# Altium schematic sheet yLINUX-2530-2022V10.SchDoc
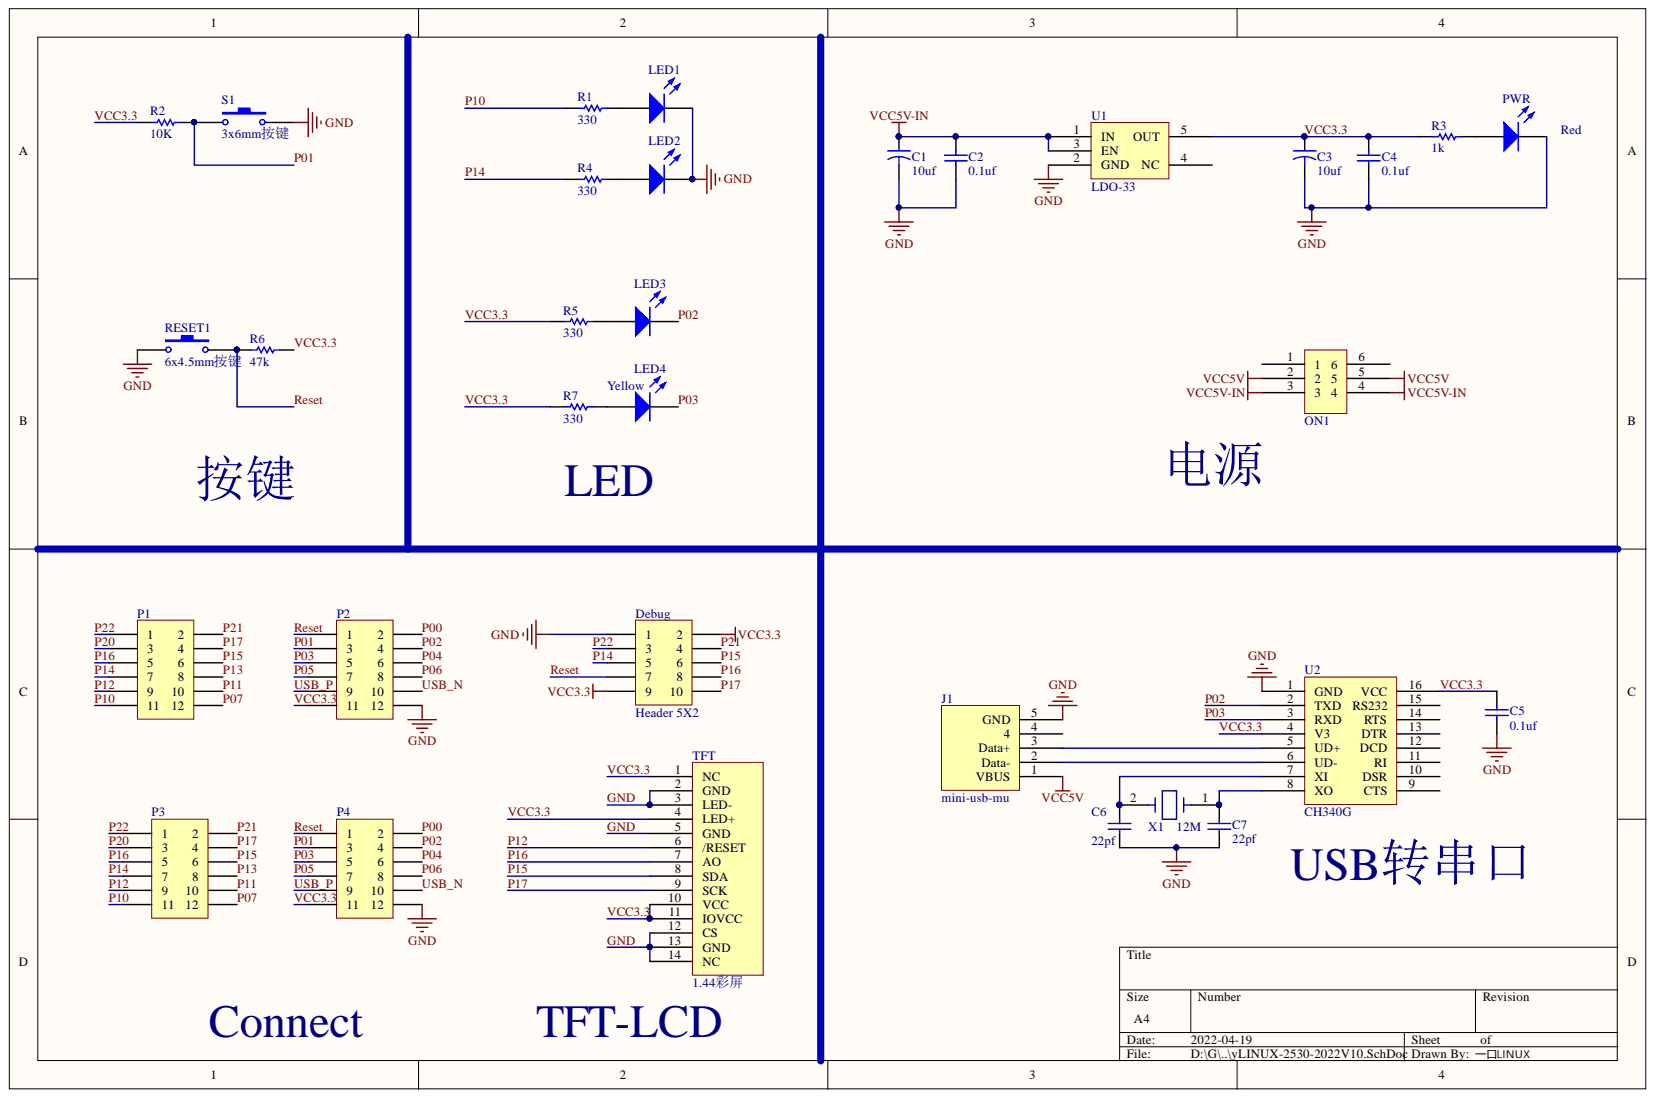
[diagram p0: yLINUX-2530-2022V10.SchDoc]

## Parts
C1  10uf  [10uf钽电容]
C2  0.1uf  [1608[0603]]
C3  10uf  [10uf钽电容]
C4  0.1uf  [1608[0603]]
C5  0.1uf  [1608[0603]]
C6  22pf  [1608[0603]]
C7  22pf  [1608[0603]]
Debug  Header 5X2  [HDR2X5]
J1  mini-usb-mu  [mini-usb-mu]
LED1  (no value)  [C0805]
LED2  (no value)  [C0805]
LED3  (no value)  [C0805]
LED4  (no value)  [C0805]
ON1  6脚开关  [6脚开关]  (value not drawn)
P1  Header 6X2  [HDR2X6]  (value not drawn)
P2  Header 6X2  [HDR2X6]  (value not drawn)
P3  Header 6X2  [HDR2X6]  (value not drawn)
P4  Header 6X2  [HDR2X6]  (value not drawn)
PWR  (no value)  [C0805]
R1  330  [1608[0603]]
R2  10k  [1608[0603]]
R3  1k  [1608[0603]]
R4  330  [1608[0603]]
R5  330  [1608[0603]]
R6  47k  [1608[0603]]
R7  330  [1608[0603]]
RESET1  6x4.5mm按键  [3*6贴片按钮]
S1  3x6mm按键  [3*6贴片按钮]
TFT  1.44彩屏  [1.44TFT]
U1  LDO-33  [LDO-33]
U2  CH340G  [SOIC16]
X1  12M  [HC-49SMD]

## Nets
VCC5V: J1.1(VBUS) ON1.2 ON1.5
VCC5V-IN: C1.1 C2.1 ON1.3 ON1.4 U1.1(IN) U1.3(EN)
GND: C1.2 C2.2 C3.2 C4.2 C5.1 C6.1 C7.1 Debug.1 J1.5(GND) LED1.2 LED2.2 P2.12 P4.12 PWR.2 RESET1.1 S1.2 TFT.2(GND) TFT.3(LED-) TFT.5(GND) TFT.12(CS) TFT.13(GND) TFT.14(NC) U1.2(GND) U2.1(GND)
P00: P2.2 P4.2
P01: P2.3 P4.3 R2.2 S1.1
P02: LED3.2 P2.4 P4.4 U2.2(TXD)
P03: LED4.2 P2.5 P4.5 U2.3(RXD)
P04: P2.6 P4.6
P05: P2.7 P4.7
P06: P2.8 P4.8
P07: P1.12 P3.12
P10: P1.11 P3.11 R1.1
P11: P1.10 P3.10
P12: P1.9 P3.9 TFT.6(/RESET)
P13: P1.8 P3.8
P14: Debug.5 P1.7 P3.7 R4.1
P15: Debug.6 P1.6 P3.6 TFT.8(SDA)
P16: Debug.8 P1.5 P3.5 TFT.7(AO)
P17: Debug.10 P1.4 P3.4 TFT.9(SCK)
P20: P1.3 P3.3
P21: Debug.4 P1.2 P3.2
P22: Debug.3 P1.1 P3.1
Reset: Debug.7 P2.1 P4.1 R6.1 RESET1.2
USB_N: P2.10 P4.10
USB_P: P2.9 P4.9
VCC3.3: C3.1 C4.1 C5.2 Debug.2 Debug.9 P2.11 P4.11 R2.1 R3.1 R5.1 R6.2 R7.1 TFT.1(NC) TFT.4(LED+) TFT.10(VCC) TFT.11(IOVCC) U1.5(OUT) U2.4(V3) U2.16(VCC)
NetC6_2: C6.2 U2.7(XI) X1.2
NetC7_2: C7.2 U2.8(XO) X1.1
NetJ1_2: J1.2(Data-) U2.6(UD-)
NetJ1_3: J1.3(Data+) U2.5(UD+)
NetLED1_1: LED1.1 R1.2
NetLED2_1: LED2.1 R4.2
NetLED3_1: LED3.1 R5.2
NetLED4_1: LED4.1 R7.2
NetPWR_1: PWR.1 R3.2

Not connected: J1 4; ON1 1 6; U1 4(NC); U2 9(CTS) 10(DSR) 11(RI) 12(DCD) 13(DTR) 14(RTS) 15(RS232)

## Library data of the parts
C1 C3 = Cap2: Capacitor; Component Kind: Standard; Library Name: 我的元件库.IntLib; PackageReference: CAPR5-4X5; Published: 23-Sep-2002; Signal Integrity: Cap; Simulation: CAP; Value: 10uf; Publisher: Altium Limited
C2 C4-C7 = Cap: Capacitor; Component Kind: Standard; LatestRevisionDate: 17-Jul-2002; LatestRevisionNote: Re-released for DXP Platform.; Library Name: 我的元件库.IntLib; PackageReference: RAD-0.3; Published: 8-Jun-2000; Signal Integrity: Cap; Simulation: CAP; Publisher: Altium Limited
  C2 C4 C5: Value: 0.1uf
  C6 C7: Value: 22pf
Debug = Header 5X2: Header, 5-Pin, Dual row; Component Kind: Standard; LatestRevisionDate: 17-Jul-2002; LatestRevisionNote: Re-released for DXP Platform.; Library Name: 我的元件库.IntLib; Signal Integrity: Connector; Publisher: Altium Limited
LED1-LED4 PWR = LED1: Typical RED GaAs LED; Component Kind: Standard; LatestRevisionDate: 08-Jul-2005; LatestRevisionNote: Mech Layer 1 removed.; Library Name: 我的元件库.IntLib; PackageReference: LED-1; Published: 8-Jun-2000; Simulation: LED1; Publisher: Altium Limited
P1-P4 = Header 6X2: Header, 6-Pin, Dual row; Component Kind: Standard; LatestRevisionDate: 17-Jul-2002; LatestRevisionNote: Re-released for DXP Platform.; Library Name: 我的元件库.IntLib; Signal Integrity: Connector; Publisher: Altium Limited
R1 R4 R5 R7 = Res Semi: Semiconductor Resistor; Value: 1k; Component Kind: Standard; LatestRevisionDate: 17-Jul-2002; LatestRevisionNote: Re-released for DXP Platform.; Library Name: 我的元件库.IntLib; PackageReference: AXIAL-0.5; Published: 8-Jun-2000; Signal Integrity: Res Semi; Simulation: RES; Publisher: Altium Limited
R2 R3 R6 = Res Semi: Semiconductor Resistor; Component Kind: Standard; LatestRevisionDate: 17-Jul-2002; LatestRevisionNote: Re-released for DXP Platform.; Library Name: 我的元件库.IntLib; PackageReference: AXIAL-0.5; Published: 8-Jun-2000; Signal Integrity: Res Semi; Simulation: RES; Publisher: Altium Limited
  R2: Value: 10K
  R3: Value: 1k
  R6: Value: 47k
RESET1 S1 = SW-PB: Switch; Component Kind: Standard; LatestRevisionDate: 17-Jul-2002; LatestRevisionNote: Re-released for DXP Platform.; Library Name: 我的元件库.IntLib; PackageReference: SPST-2; Published: 24-Mar-1999; Publisher: Altium Limited
X1 = XTAL11.0592: Component Kind: Standard; Library Name: 我的元件库.IntLib
J1 = mini-usb-mu: Component Kind: Standard; Library Name: 我的元件库.IntLib
ON1 = 6脚开关: Component Kind: Standard; Library Name: 我的元件库.IntLib
TFT = 1.44彩屏: Component Kind: Standard; Library Name: 我的元件库.IntLib
U1 = LDO-33: Component Kind: Standard; Library Name: 我的元件库.IntLib
U2 = CH340G: Component Kind: Standard; Library Name: 我的元件库.IntLib
